# Altium schematic sheet Main.SchDoc
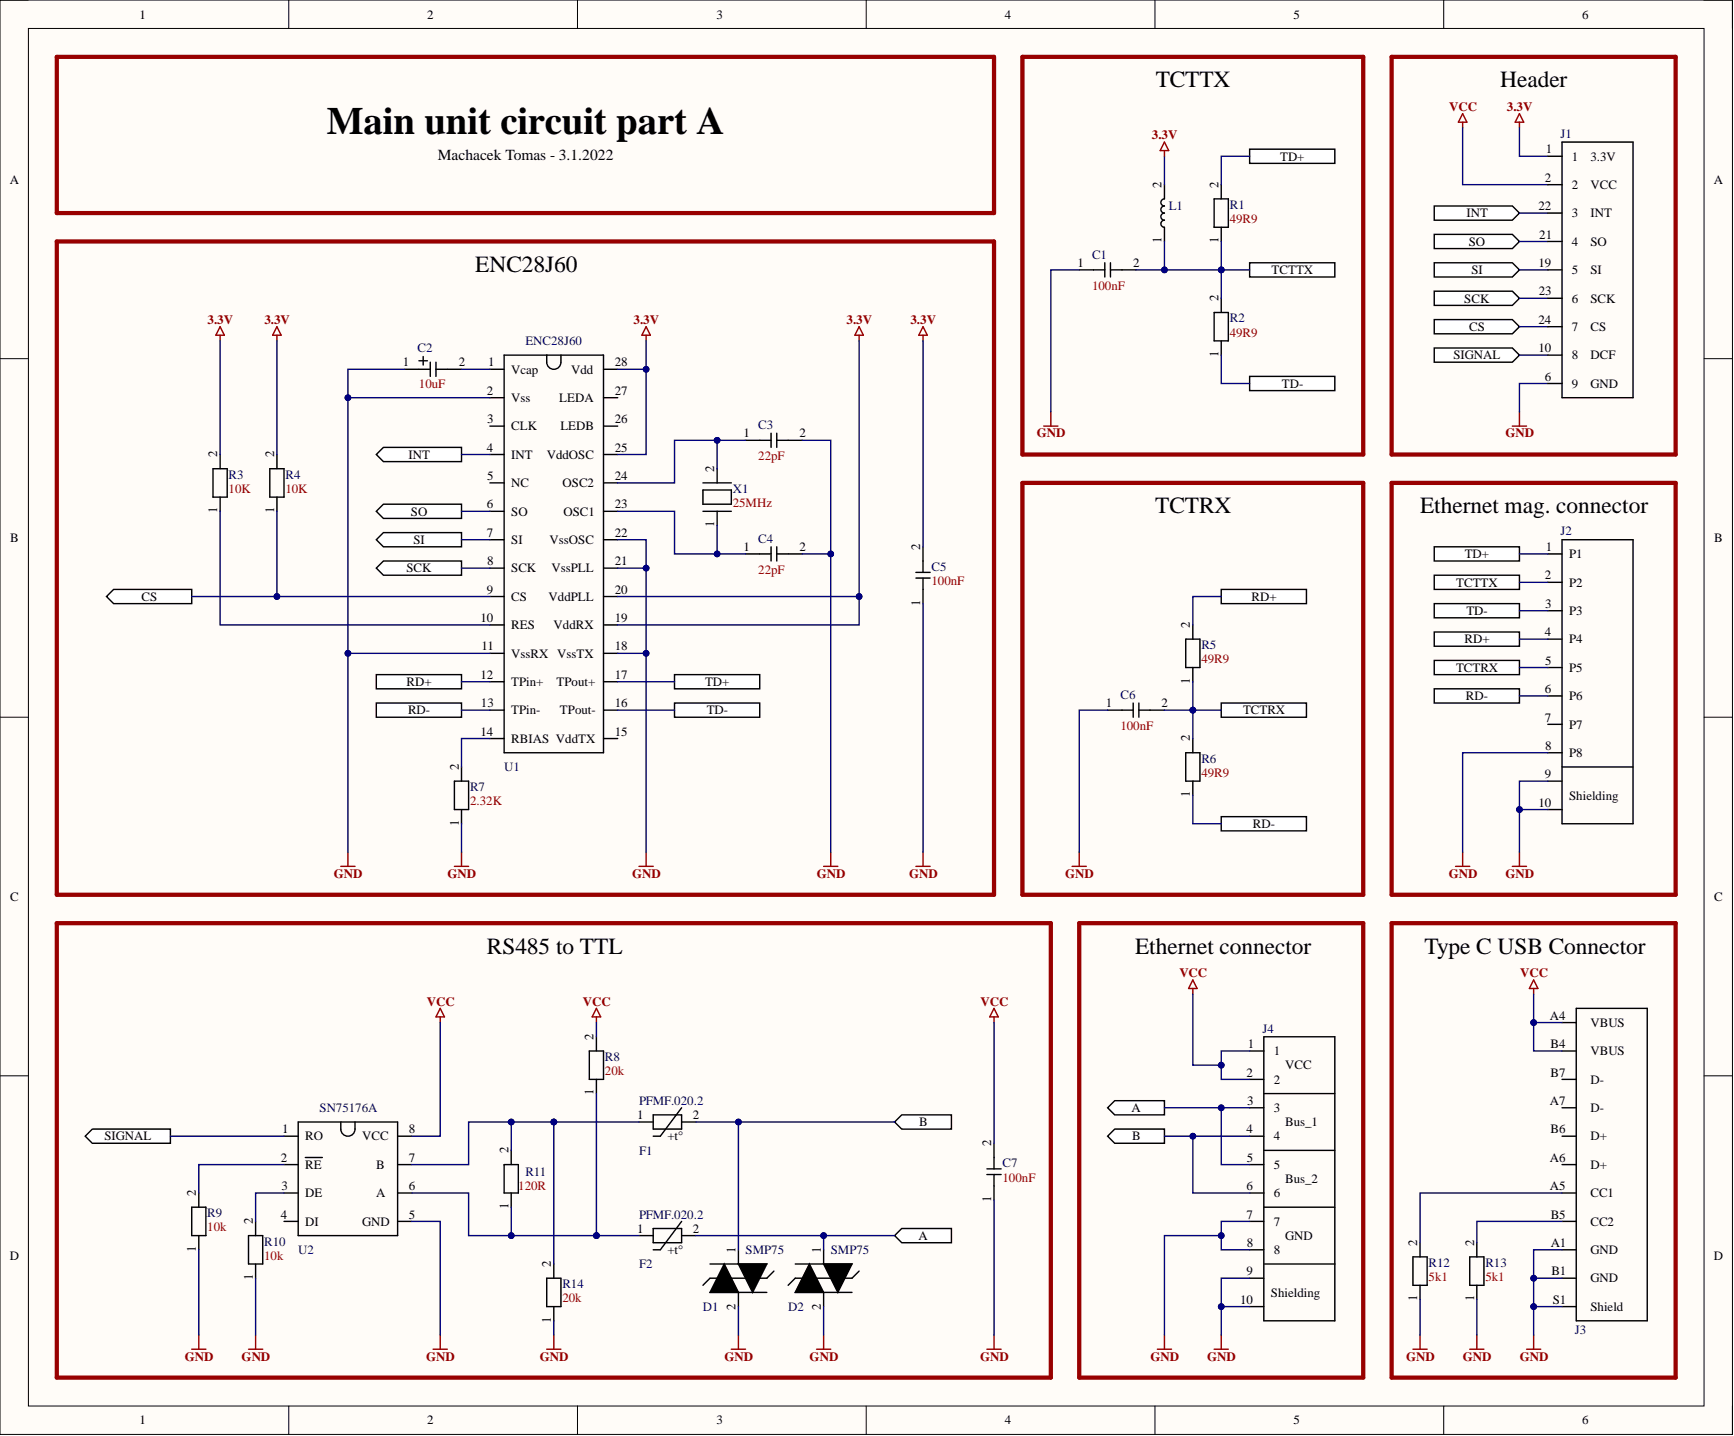
[diagram p0: Main.SchDoc]

## Parts
C1  value 100nF  [MLCC_capacitator]  (hidden comment: Capacitator_MLCC)
C2  value 10uF  [Tantalum_capacitor]  (hidden comment: Capacitator_aluminium)
C3  value 22pF  [MLCC_capacitator]  (hidden comment: Capacitator_MLCC)
C4  value 22pF  [MLCC_capacitator]  (hidden comment: Capacitator_MLCC)
C5  value 100nF  [MLCC_capacitator]  (hidden comment: Capacitator_MLCC)
C6  value 100nF  [MLCC_capacitator]  (hidden comment: Capacitator_MLCC)
C7  value 100nF  [MLCC_capacitator]  (hidden comment: Capacitator_MLCC)
D1  SMP75  [SMP75]
D2  SMP75  [SMP75]
F1  PFMF.020.2  [PFMF.020.2]
F2  PFMF.020.2  [PFMF.020.2]
J1  20x2_header  [20x2_Header]  (value not drawn)
J2  Ethernet_mag_connector  [Ethernet_mag_connector]
J3  USB_C_connector  [Usb_c_right_angle]  (value not drawn)
J4  Ethernet_connector  [Ethernet_connector]
L1  Ferrite_bead  [Ferrite_bead]  (value not drawn)
R1  value 49R9  [Resistor]  (hidden comment: Resistor)
R2  value 49R9  [Resistor]  (hidden comment: Resistor)
R3  value 10K  [Resistor]  (hidden comment: Resistor)
R4  value 10K  [Resistor]  (hidden comment: Resistor)
R5  value 49R9  [Resistor]  (hidden comment: Resistor)
R6  value 49R9  [Resistor]  (hidden comment: Resistor)
R7  value 2.32K  [Resistor]  (hidden comment: Resistor)
R8  value 20k  [Resistor]  (hidden comment: Resistor)
R9  value 10k  [Resistor]  (hidden comment: Resistor)
R10  value 10k  [Resistor]  (hidden comment: Resistor)
R11  value 120R  [Resistor]  (hidden comment: Resistor)
R12  value 5k1  [Resistor]  (hidden comment: Resistor)
R13  value 5k1  [Resistor]  (hidden comment: Resistor)
R14  value 20k  [Resistor]  (hidden comment: Resistor)
U1  ENC28J60  [ENC28J60]
U2  SN75176A  [SN75176A]
X1  value 25MHz  [Crystal_25MHz]  (hidden comment: Crystal_1)

## Ports
A, B, CS, INT, RD+, RD-, SCK, SI, SIGNAL, SO, TCTRX, TCTTX, TD+, TD-

## Nets
3.3V: C5.2 J1.1 L1.2 R3.2 R4.2 U1.19(VddRX) U1.20(VddPLL) U1.25(VddOSC) U1.28(Vdd)
GND: C1.1 C2.1 C3.2 C4.2 C5.1 C6.1 C7.1 D1.2 D2.2 J1.6 J2.8(P8) J2.9 J2.10 J3.A1(GND) J3.B1(GND) J3.S1(Shield) J4.7 J4.8 J4.9 J4.10 R7.1 R9.1 R10.1 R12.1 R13.1 R14.1 U1.2(Vss) U1.11(VssRX) U1.18(VssTX) U1.21(VssPLL) U1.22(VssOSC) U2.5(GND)
VCC: C7.2 J1.2 J3.A4(VBUS) J3.B4(VBUS) J4.1 J4.2 R8.2 U2.8(VCC)
A: D2.1 F2.2 J4.3 J4.5 ; port A
B: D1.1 F1.2 J4.4 J4.6 ; port B
CS: J1.24 R4.1 U1.9(CS) ; port CS
INT: J1.22 U1.4(INT) ; port INT
SCK: J1.23 U1.8(SCK) ; port SCK
SI: J1.19 U1.7(SI) ; port SI
SIGNAL: J1.10 U2.1(RO) ; port SIGNAL
SO: J1.21 U1.6(SO) ; port SO
TCTRX: C6.2 J2.5(P5) R5.1 R6.2 ; port TCTRX
TCTTX: C1.2 J2.2(P2) L1.1 R1.1 R2.2 ; port TCTTX
NetC2_2: C2.2 U1.1(Vcap)
NetC3_1: C3.1 U1.24(OSC2) X1.2
NetC4_1: C4.1 U1.23(OSC1) X1.1
NetF1_1: F1.1 R11.2 R14.2 U2.7(B)
NetF2_1: F2.1 R8.1 R11.1 U2.6(A)
NetJ2_1: J2.1(P1) R1.2 U1.17(TPout+)
NetJ2_3: J2.3(P3) R2.1 U1.16(TPout-)
NetJ2_4: J2.4(P4) R5.2 U1.12(TPin+)
NetJ2_6: J2.6(P6) R6.1 U1.13(TPin-)
NetJ3_A5: J3.A5(CC1) R12.2
NetJ3_B5: J3.B5(CC2) R13.2
NetR3_1: R3.1 U1.10(RES)
NetR7_2: R7.2 U1.14(RBIAS)
NetR9_2: R9.2 U2.2(RE)
NetR10_2: R10.2 U2.3(DE)

Not connected: J2 7(P7); J3 A6(D+) A7(D-) B6(D+) B7(D-); U1 3(CLK) 5(NC) 15(VddTX) 26(LEDB) 27(LEDA); U2 4(DI)

## Library data of the parts
C1 C3-C7 = Capacitator_MLCC: MLCC capacitator - 1206; Component Kind: Standard; Library Name: Schematic_library.SchLib
C2 = Capacitator_aluminium: Aluminum capacitator - filtration; Component Kind: Standard; Library Name: Schematic_library.SchLib; Supplier 1: Mouser; Supplier Part Number 1: 80-T491A106M010
D1 D2 = SMP75: Bidirectional crowbar protection; Component Kind: Standard; Library Name: Schematic_library.SchLib; Supplier 1: Mouser; Supplier Part Number 1: 511-SMP75-8
F1 F2 = PFMF.020.2: SMD fuse; Component Kind: Standard; Library Name: Schematic_library.SchLib; Supplier 1: Mouser; Supplier Part Number 1: 693-PFMF.020.2
J1 = 20x2_header: 40 position pin header; Component Kind: Standard; Library Name: Schematic_library.SchLib; Supplier 1: Mouser; Supplier Part Number 1: 200-CES12001TD
J2 = Ethernet_mag_connector: Ethernet magnetic connector; Component Kind: Standard; Library Name: Schematic_library.SchLib; Supplier 1: Mouser; Supplier Part Number 1: 571-5-2301995-2
J3 = USB_C_connector: USB type C; Component Kind: Standard; Library Name: Schematic_library.SchLib
J4 = Ethernet_connector: Ethernet connector; Component Kind: Standard; Library Name: Schematic_library.SchLib; Supplier 1: Mouser; Supplier Part Number 1: 571-6368150-1
L1 = Ferrite_bead: Multilayer Ferrite Bead; Component Kind: Standard; Library Name: Schematic_library.SchLib; Supplier 1: Mouser; Supplier Part Number 1: 70-ILB1206ER600V
R1-R14 = Resistor: SMD resistor - 1206; Component Kind: Standard; Library Name: Schematic_library.SchLib
U1 = ENC28J60: Stand-Alone Ethernet Controller with SPI Interface; Component Kind: Standard; Library Name: Schematic_library.SchLib; Supplier 1: Mouser; Supplier Part Number 1: 579-ENC28J60/SS
U2 = SN75176A: RS485 transreciever; Component Kind: Standard; Library Name: Schematic_library.SchLib; Supplier 1: Mouser; Supplier Part Number 1: 595-SN75176ADR
X1 = Crystal_1: Quartz crystal 25MHz; Component Kind: Standard; Library Name: Schematic_library.SchLib
